# Altium schematic sheet motor.SchDoc
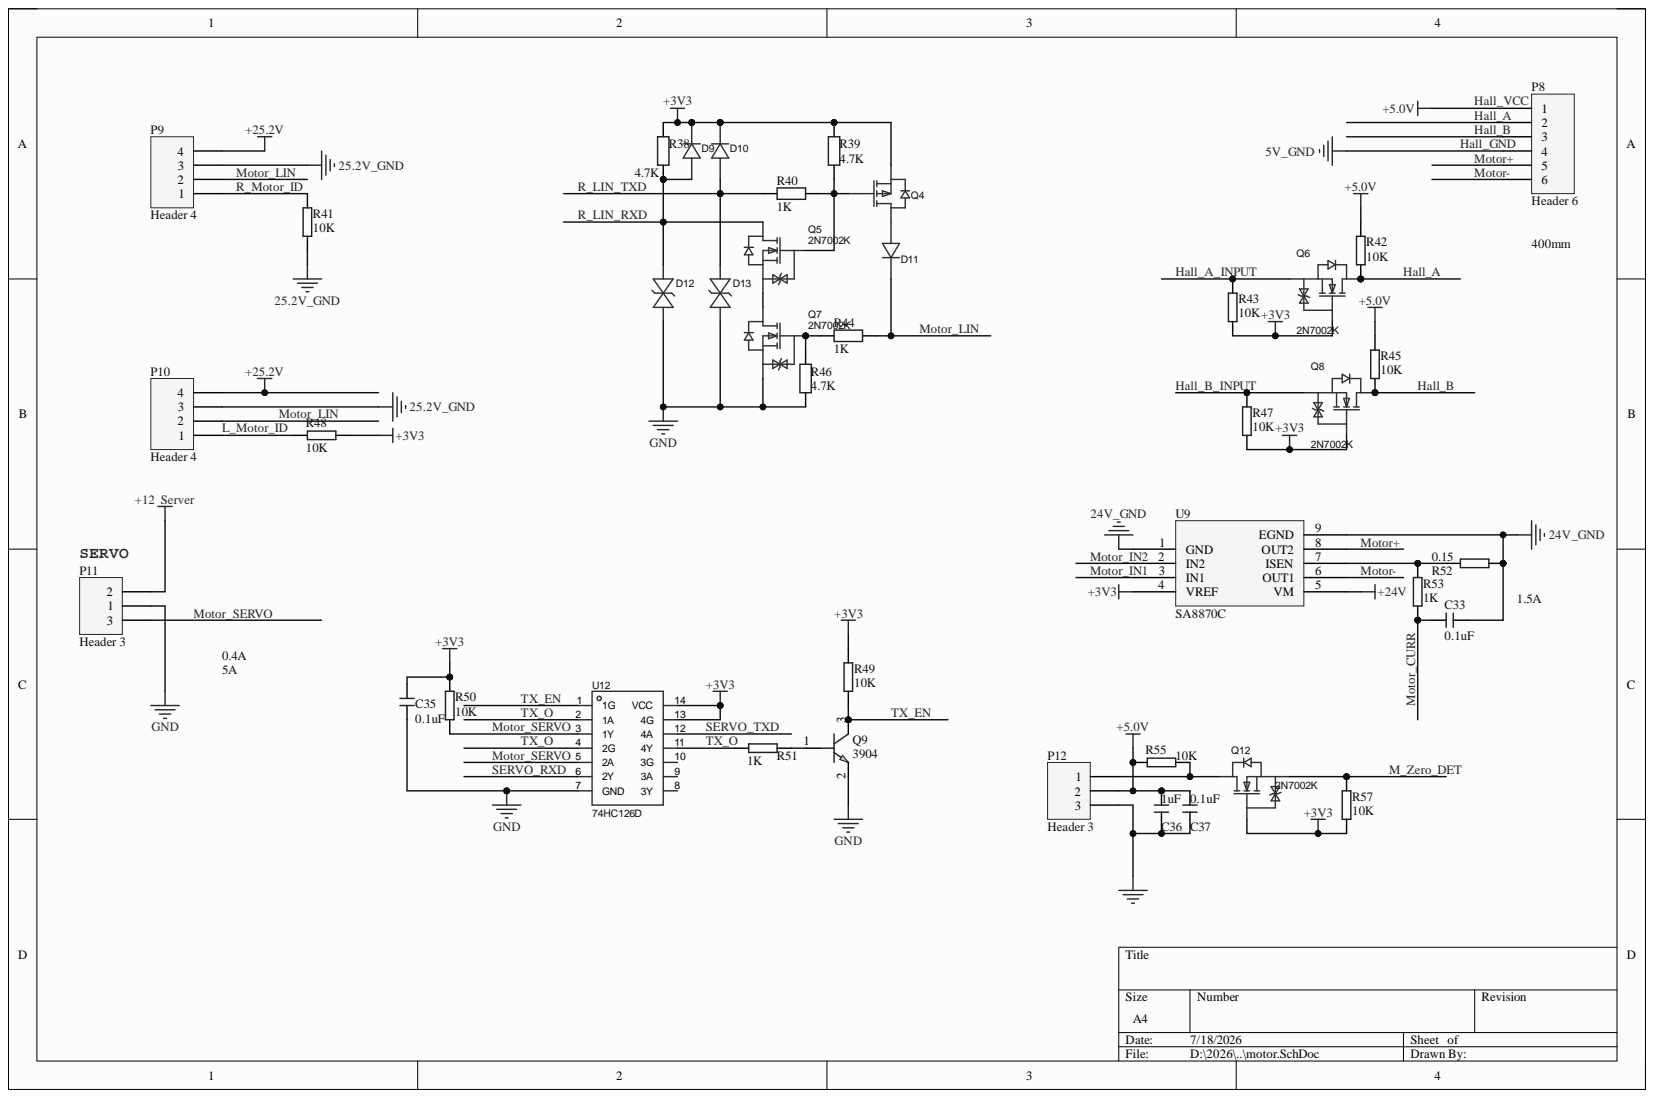
[diagram p0: motor.SchDoc]

## Parts
C33  0.1uF  [C 0603_L]
C35  0.1uF  [C 0603_L]
C36  1uF  [C 0603_L]
C37  0.1uF  [C 0603_L]
D9  1N4148W-TP  [SOD-123_L2.8-W1.8-LS3.7-RD]  (value not drawn)
D10  1N4148W-TP  [SOD-123_L2.8-W1.8-LS3.7-RD]  (value not drawn)
D11  1N4148W-TP  [SOD-123_L2.8-W1.8-LS3.7-RD]  (value not drawn)
D12  PSD03C-LF-T7  [SOD-323_L1.8-W1.3-LS2.5-BI]  (value not drawn)
D13  PSD03C-LF-T7  [SOD-323_L1.8-W1.3-LS2.5-BI]  (value not drawn)
P8  Header 6  [PH2.0-LS-6P]
P9  Header 4  [PH2.0-LS-4P]
P10  Header 4  [PH2.0-LS-4P]
P11  Header 3  [XH2.54-LS-3P]
P12  Header 3  [PH2.0-LS-3P]
Q4  BSS84-7-F  [SOT-23_L2.9-W1.3-P1.90-LS2.4-BR]  (value not drawn)
Q5  2N7002K  [SOT-23_L2.9-W1.3-P1.90-LS2.4-BR]
Q6  2N7002K  [SOT-23_L2.9-W1.3-P1.90-LS2.4-BR]
Q7  2N7002K  [SOT-23_L2.9-W1.3-P1.90-LS2.4-BR]
Q8  2N7002K  [SOT-23_L2.9-W1.3-P1.90-LS2.4-BR]
Q9  3904  [sot-23 -3904]
Q12  2N7002K  [SOT-23_L2.9-W1.3-P1.90-LS2.4-BR]
R38  value 4.7K  [R 0603_L]  (hidden comment: 100R)
R39  value 4.7K  [R 0603_L]  (hidden comment: 100R)
R40  value 1K  [R 0603_L]  (hidden comment: 100R)
R41  10K  [R 0603_L]
R42  10K  [R 0603_L]
R43  10K  [R 0603_L]
R44  value 1K  [R 0603_L]  (hidden comment: 100R)
R45  10K  [R 0603_L]
R46  value 4.7K  [R 0603_L]  (hidden comment: 100R)
R47  10K  [R 0603_L]
R48  10K  [R 0603_L]
R49  10K  [R 0603_L]
R50  10K  [R 0603_L]
R51  1K  [R 0603_L]
R52  0.15  [R 2010_L]
R53  1K  [R 0603_L]
R55  10K  [R 0603_L]
R57  10K  [R 0603_L]
U9  SA8870C  [ESOP-8_L4.9-W3.9-P1.27-LS6.0-BL-EP]
U12  74HC126D  [SOIC-14_L8.7-W3.9-P1.27-LS6.0-BL]

## Nets
24V_GND: C33.2 R52.1 U9.1(GND) U9.5(VM) U9.9(EGND)
25.2V_GND: P9.3 P9.4 P10.3 P10.4 R41.2
+3V3: C35.2 D9.1 D10.1 Q4.2 Q6.1 Q8.1 Q12.1 R38.1 R39.1 R43.2 R47.2 R48.2 R49.2 R50.2 R57.2 U9.4(VREF) U12.13(4G) U12.14(VCC)
+12  Server: P11.2
GND: C35.1 C36.2 C37.2 D12.1 D13.1 P11.1 P12.3 Q7.2 Q9.2 R46.2 U12.7(GND)
Hall_A: P8.2 Q6.3 R42.2
Hall_A_INPUT: Q6.2 R43.1
Hall_B: P8.3 Q8.3 R45.2
Hall_B_INPUT: Q8.2 R47.1
Hall_GND: P8.4
Hall_VCC: C36.1 C37.1 P8.1 P12.2 R42.1 R45.1 R55.1
L_Motor_ID: P10.1 R48.1
M_Zero_DET: Q12.2 R57.1
Motor+: P8.5 U9.8(OUT2)
Motor-: P8.6 U9.6(OUT1)
Motor_CURR: C33.1 R53.1
Motor_IN1: U9.3(IN1)
Motor_IN2: U9.2(IN2)
Motor_LIN: D11.1 P9.2 P10.2 R44.2
Motor_SERVO: P11.3 R50.1 U12.3(1Y) U12.5(2A)
R_LIN_RXD: D9.2 D12.2 Q5.3 R38.2
R_LIN_TXD: D10.2 D13.2 R40.1
R_Motor_ID: P9.1 R41.1
SERVO_RXD: U12.6(2Y)
SERVO_TXD: U12.12(4A)
TX_EN: Q9.3 R49.1 U12.1(1G)
TX_O: R51.2 U12.2(1A) U12.4(2G) U12.11(4Y)
NetD11_2: D11.2 Q4.3
NetP12_1: P12.1 Q12.3 R55.2
NetQ4_1: Q4.1 Q5.1 R39.2 R40.2
NetQ5_2: Q5.2 Q7.3
NetQ7_1: Q7.1 R44.1 R46.1
NetQ9_1: Q9.1 R51.1
NetR52_2: R52.2 R53.2 U9.7(ISEN)

Not connected: U12 8(3Y) 9(3A) 10(3G)

## Library data of the parts
C33 C35-C37 = C: 贴片电容; Component Kind: Standard; Library Name: GS_SchLib.SchLib
D9-D11 = 1N4148W-TP: 二极管配置:-;功率:400mW;直流反向耐压(Vr):100V;平均整流电流(Io):150mA;正向压降(Vf):1.25V@150mA;反向电流(Ir):1μA@75V;反向恢复时间(trr):4ns;工作温度:-65℃~+150℃@(Tj);; Manufacturer: MCC(美微科); AddInBom: yes; Average Rectified Current (Io): 150mA; ModelId: 7884390a25fb4c08947731ebfba7e3f8聨0819f05c4eef4c71ace90d822a990e87; ModelTransform: 144.8816,62.992,0,0,0,0,0,0,0; Power Dissipation: 400mW; Reverse Recovery Time (trr): 4ns; Reverse Voltage (Vr): 100V; Component Kind: Standard; ConvertToPcb: yes; Datasheet: https://atta.szlcsc.com/upload/public/pdf/source/20161112/1478931639357.pdf; Device: 1N4148W-TP; Diode Configuration: -; FootprintName: SOD-123_L2.8-W1.8-LS3.7-RD; Forward Voltage (Vf@If): 1.25V@150mA; JLCPCB Part Class: Extended Part; LCSC Part Name: 100V 150mA 4ns; ManufacturerPart: 1N4148W-TP; ModelName: SOD-123_L2.8-W1.8-LS3.7-RD; Operating Temperature: -65℃~+150℃@(Tj); Reverse Leakage Current: 1μA@75V; Supplier: LCSC; Supplier Footprint: SOD-123; SupplierPart: C77978; Symbol: 1N4148W-TP
D12 D13 = PSD03C-LF-T7: 极性:双向;反向截止电压(Vrwm):3.3V;击穿电压(最小值):4V;最大钳位电压:7V;; Manufacturer: PROTEK; AddInBom: yes; Breakdown Voltage (Min): 4V; Maximum Clamping Voltage: 7V; ModelId: e9dd044ee7bf4221b3b795eb56bce7c0聨0819f05c4eef4c71ace90d822a990e87; ModelName: SOD-323_L1.7-W1.3-H1.1-LS2.5; ModelTransform: 98.9,51.2,0,0,0,0,0,0,0; Polarity: 双向; Reverse Stand-Off Voltage (Vrwm): 3.3V; Component Kind: Standard; ConvertToPcb: yes; Datasheet: https://atta.szlcsc.com/upload/public/pdf/source/20171026/C139300_15089856513231059587.pdf; Device: PSD03C-LF-T7; FootprintName: SOD-323_L1.8-W1.3-LS2.5-BI; JLCPCB Part Class: Extended Part; LCSC Part Name: 双向 3.3V; ManufacturerPart: PSD03C-LF-T7; Supplier: LCSC; Supplier Footprint: SOD-323; SupplierPart: C139300; Symbol: PSD03C-LF-T7
P8 = Header 6: Header, 6-Pin; Component Kind: Standard; LatestRevisionDate: 17-Jul-2002; LatestRevisionNote: Re-released for DXP Platform.; Library Name: Miscellaneous Connectors.IntLib; Signal Integrity: Connector; Publisher: Altium Limited
P9 P10 = Header 4: Header, 4-Pin; Component Kind: Standard; LatestRevisionDate: 17-Jul-2002; LatestRevisionNote: Re-released for DXP Platform.; Library Name: Miscellaneous Connectors.IntLib; Signal Integrity: Connector; Publisher: Altium Limited
P11 P12 = Header 3: Header, 3-Pin; Component Kind: Standard; LatestRevisionDate: 17-Jul-2002; LatestRevisionNote: Re-released for DXP Platform.; Library Name: Miscellaneous Connectors.IntLib; Signal Integrity: Connector; Publisher: Altium Limited
Q4 = BSS84-7-F: 类型:P沟道;漏源电压(Vdss):50V;连续漏极电流(Id):130mA;功率(Pd):300mW;导通电阻(RDS(on)@Vgs,Id):10Ω@5V,100mA;阈值电压(Vgs(th)@Id):2V@1mA;; Manufacturer: DIODES(美台); AddInBom: yes; Continuous Drain Current (Id): 130mA; Drain Source Voltage (Vdss): 50V; Gate Threshold Voltage (Vgs(th)@Id): 2V@1mA; ModelId: c62791f0466c4ae2a892c056b845b0ca聨0819f05c4eef4c71ace90d822a990e87; ModelName: SOT-23-3P_L2.9-W1.3-H1.0-LS2.4-P0.95; ModelTransform: 98.64468,114.173,0,180,0,0,0,0,0; Power Dissipation (Pd): 300mW; Type: P沟道; Component Kind: Standard; ConvertToPcb: yes; Datasheet: https://atta.szlcsc.com/upload/public/pdf/source/20161024/1477288743654.pdf; Device: BSS84-7-F; Drain Source On Resistance (RDS(on)@Vgs,Id): 10Ω@5V,100mA; FootprintName: SOT-23_L2.9-W1.3-P1.90-LS2.4-BR; JLCPCB Part Class: Extended Part; LCSC Part Name: P沟道 50V 130mA; ManufacturerPart: BSS84-7-F; Supplier: LCSC; Supplier Footprint: SOT-23(SOT-23-3); SupplierPart: C85202; Symbol: BSS84-7-F
Q5-Q8 Q12 = 2N7002K: 数量:1个N沟道;漏源电压(Vdss):60V;连续漏极电流(Id):300mA;导通电阻(RDS(on)):2Ω@10V;耗散功率(Pd):350mW;阈值电压(Vgs(th)):2.5V@1mA;; Manufacturer: EIC; AddInBom: yes; Current - Continuous Drain(Id): 300mA; Drain to Source Voltage: 60V; Gate Charge(Qg): 800pC@4.5V; Input Capacitance(Ciss): 50pF; ModelId: c62791f0466c4ae2a892c056b845b0ca聨0819f05c4eef4c71ace90d822a990e87; ModelName: SOT-23-3P_L2.9-W1.3-H1.0-LS2.4-P0.95; ModelTransform: 98.64468,114.173,0,180,0,0,0.001,-0.002,0; Number: 1个N沟道; Output Capacitance(Coss): 25pF; Pd - Power Dissipation: 350mW; RDS(on): 2Ω@10V; Reverse Transfer Capacitance (Crss@Vds): 5pF; Symbol: 2N7002K_C2978790; Type: N沟道; Component Kind: Standard; ConvertToPcb: yes; Datasheet: https://item.szlcsc.com/datasheet/2N7002K/3393615.html; Device: 2N7002K; FootprintName: SOT-23_L2.9-W1.3-P1.90-LS2.4-BR; Gate Threshold Voltage (Vgs(th)): 2.5V@1mA; JLCPCB Part Class: Extended Part; LCSC Part Name: N沟道增强型MOSFET,电流:300mA,耐压:60V; ManufacturerPart: 2N7002K; Operating Temperature: -55℃~+150℃; Supplier: LCSC; Supplier Footprint: SOT-23; SupplierPart: C2978790
Q9 = NPN: Text Field1: 20; Component Kind: Standard; Text Field2: 20
R38-R40 R44 R46 = Res2: Resistor; Manufacturer: Generic Components; Class: Passive; Component Kind: Standard; Published: 08/06/2000; SubClass: Resistor; Publisher: Altium Hobart Technology Centre
R41-R43 R45 R47-R53 R55 R57 = RES3-SMD: SMD筿; Component Kind: Standard; Library Name: Orson SCH.SCHLIB
U9 = Component_1: Component Kind: Standard; Library Name: Schlib1.SchLib
U12 = 74HC126D: 输入类型:-;工作电压:2V~6V;元件数:4;每个元件位数:1;灌电流(IOL):7.8mA;拉电流(IOH):7.8mA;; Manufacturer: TOSHIBA(东芝); AddInBom: yes; Current - Output High(IOH): 7.8mA; Logic Family: 74HC; ModelId: 8dbf5b715d42428c85558507ae5a252b聨0819f05c4eef4c71ace90d822a990e87; ModelName: SOIC-14_L8.6-W3.9-H1.8-LS6.0-P1.27; ModelTransform: 338.583,236.22,0,0,0,0,0,0.001,0; Number of Bits per Element: 1; Number of Elements: 4; output type: 三态; Propagation Delay: 10ns@6.0V,50pF; Quiescent Current: 160uA; Voltage - Supply: 2V~6V; Channel Type: -; Component Kind: Standard; ConvertToPcb: yes; Current - Output Low(IOL): 7.8mA; Datasheet: https://item.szlcsc.com/datasheet/74HC126D/2767587.html; Device: 74HC126D; FootprintName: SOIC-14_L8.7-W3.9-P1.27-LS6.0-BL; Input type: -; JLCPCB Part Class: Extended Part; LCSC Part Name: 74HC126D; ManufacturerPart: 74HC126D; Operating Temperature: -40℃~+125℃; Supplier: LCSC; Supplier Footprint: SOIC-14; SupplierPart: C2675671; Symbol: 74HC126D
